# Altium schematic sheet CONTROL-PANEL.SchDoc
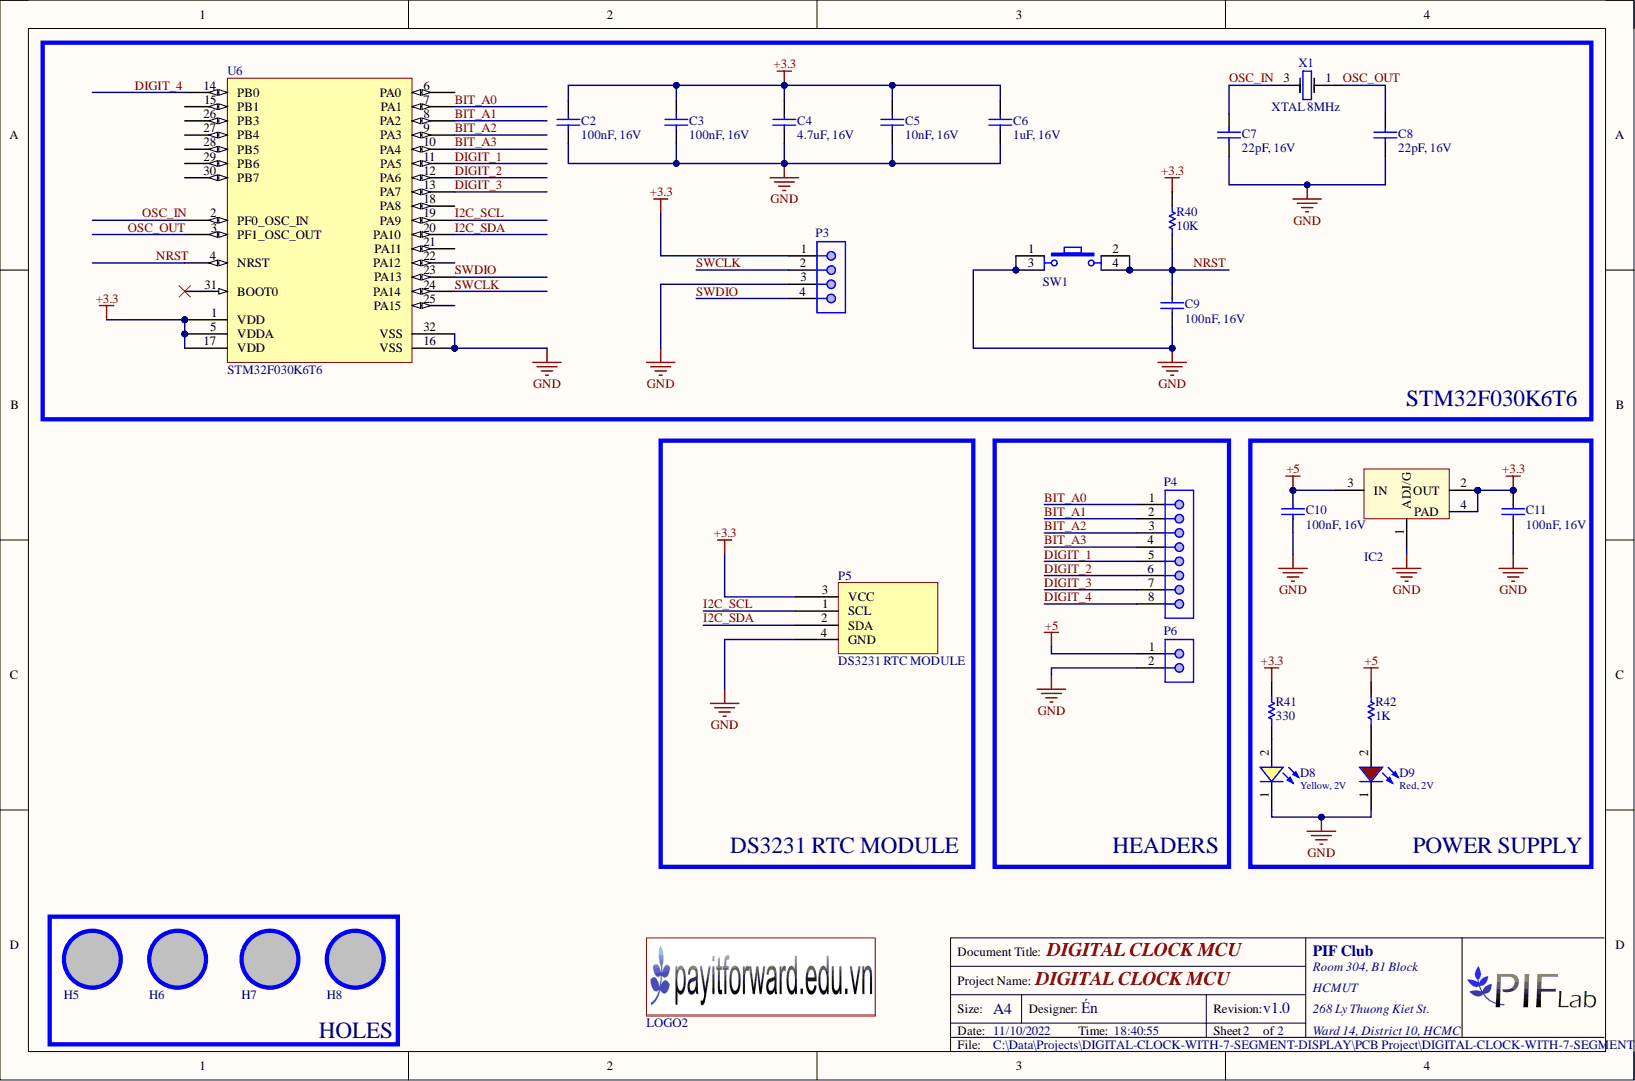
[diagram p0: CONTROL-PANEL.SchDoc]

## Parts
C2  value 100nF, 16V  [CAP-SM-0603]  (hidden comment: Cap Non-Pol SMD)
C3  value 100nF, 16V  [CAP-SM-0603]  (hidden comment: Cap Non-Pol SMD)
C4  value 4.7uF, 16V  [CAP-SM-0603]  (hidden comment: Cap Non-Pol SMD)
C5  value 10nF, 16V  [CAP-SM-0603]  (hidden comment: Cap Non-Pol SMD)
C6  value 1uF, 16V  [CAP-SM-0603]  (hidden comment: Cap Non-Pol SMD)
C7  value 22pF, 16V  [CAP-SM-0603]  (hidden comment: Cap Non-Pol SMD)
C8  value 22pF, 16V  [CAP-SM-0603]  (hidden comment: Cap Non-Pol SMD)
C9  value 100nF, 16V  [CAP-SM-0603]  (hidden comment: Cap Non-Pol SMD)
C10  value 100nF, 16V  [CAP-SM-0603]  (hidden comment: Cap Non-Pol SMD)
C11  value 100nF, 16V  [CAP-SM-0603]  (hidden comment: Cap Non-Pol SMD)
D8  Yellow, 2V  [WL-SMCW_0603_H=0.7mm]
D9  Red, 2V  Forward Voltage typ.: 2V  [WL-SMCW_0603_H=0.7mm]
H5  Hole  [LO KHOAN 3MM]  (value not drawn)
H6  Hole  [LO KHOAN 3MM]  (value not drawn)
H7  Hole  [LO KHOAN 3MM]  (value not drawn)
H8  Hole  [LO KHOAN 3MM]  (value not drawn)
IC2  LM1117  [SOT223-4N]  (value not drawn)
LOGO2  LOGO PIF  [PIF LOGO SMALL TOP]  (value not drawn)
P3  61900411121  [61900411121]  (value not drawn)
P4  62000811622  [62000811622]  (value not drawn)
P5  DS3231 RTC MODULE  [DS3231 RTC MODULE]
P6  62000211622  [62000211622]  (value not drawn)
R40  value 10K  [RES-SM-0603]  (hidden comment: RESISTOR SMD)
R41  value 330  [RES-SM-0603]  (hidden comment: RESISTOR SMD)
R42  value 1K  [RES-SM-0603]  (hidden comment: RESISTOR SMD)
SW1  SW  [Tact SW 4 pin]
U6  STM32F030K6T6  [STM32F030K6T6]
X1  XTAL 8MHz  [XTAL 3225]

## Nets
+3.3: C2.2 C3.2 C4.2 C5.2 C6.2 C11.2 IC2.2(OUT) IC2.4(PAD) P3.1 P5.3(VCC) R40.2 R41.2 U6.1(VDD) U6.5(VDDA) U6.17(VDD)
+5: C10.2 IC2.3(IN) P6.1 R42.2
GND: C2.1 C3.1 C4.1 C5.1 C6.1 C7.1 C8.1 C9.1 C10.1 C11.1 D8.1 D9.1 IC2.1(ADJ/G) P3.3 P5.4(GND) P6.2 SW1.1 SW1.3 U6.16(VSS) U6.32(VSS)
BIT_A0: P4.1 U6.7(PA1)
BIT_A1: P4.2 U6.8(PA2)
BIT_A2: P4.3 U6.9(PA3)
BIT_A3: P4.4 U6.10(PA4)
DIGIT_1: P4.5 U6.11(PA5)
DIGIT_2: P4.6 U6.12(PA6)
DIGIT_3: P4.7 U6.13(PA7)
DIGIT_4: P4.8 U6.14(PB0)
I2C_SCL: P5.1(SCL) U6.19(PA9)
I2C_SDA: P5.2(SDA) U6.20(PA10)
NRST: C9.2 R40.1 SW1.2 SW1.4 U6.4(NRST)
OSC_IN: C7.2 U6.2(PF0_OSC_IN) X1.3
OSC_OUT: C8.2 U6.3(PF1_OSC_OUT) X1.1
SWCLK: P3.2 U6.24(PA14)
SWDIO: P3.4 U6.23(PA13)
NetD8_2: D8.2 R41.1
NetD9_2: D9.2 R42.1

Not connected: U6 6(PA0) 15(PB1) 18(PA8) 21(PA11) 22(PA12) 25(PA15) 26(PB3) 27(PB4) 28(PB5) 29(PB6) 30(PB7) 31(BOOT0)

## Library data of the parts
C2 C10 = Cap Non-Pol SMD: Capacitor; Value: 100nF, 16V; Component Kind: Standard; Library Name: PIF_Library.IntLib
C3-C9 C11 = Cap Non-Pol SMD: Capacitor; Component Kind: Standard; Library Name: PIF_Library.IntLib
D8 = 150060YS75000: WL-SMCW SMT Mono-color Chip LED Waterclear, size 0603, Yellow, 2V, 140deg; Chip Technology: AlInGaP; Dominant Wavelength typ.: 590nm; Luminous Intensity typ.: 120mcd; Peak Wavelength typ.: 595nm; Component Kind: Standard; ComponentLink1Description: Manufacturer; ComponentLink1URL: http://www.we-online.de; ComponentLink2Description: Datasheet; ComponentLink2URL: https://www.we-online.com/catalog/datasheet/150060YS75000.pdf; Emitting Color: Yellow; Forward Voltage typ.: 2V; Library Name: Altium_WL-SMCW (rev20a).IntLib; Mounting Technology: SMT; Operating Temperature: -40 to +85degC; Packaging: Tape and Reel; Part Number: 150060YS75000; Published Date: December 2019; Size: 0603; Viewing Angle: 140deg; Publisher: Wurth Elektronik
D9 = 150060RS75000: WL-SMCW SMT Mono-color Chip LED Waterclear, size 0603, Red, 2V, 140deg; Chip Technology: AlInGaP; Dominant Wavelength typ.: 625nm; Luminous Intensity typ.: 250mcd; Peak Wavelength typ.: 630nm; Component Kind: Standard; ComponentLink1Description: Manufacturer; ComponentLink1URL: http://www.we-online.de; ComponentLink2Description: Datasheet; ComponentLink2URL: https://www.we-online.com/catalog/datasheet/150060RS75000.pdf; Emitting Color: Red; Library Name: Altium_WL-SMCW (rev20a).IntLib; Mounting Technology: SMT; Operating Temperature: -40 to +85degC; Packaging: Tape and Reel; Part Number: 150060RS75000; Published Date: December 2019; Size: 0603; Viewing Angle: 140deg; Publisher: Wurth Elektronik
H5-H8 = Hole: Hole 3mm; Component Kind: Standard; Library Name: PIF_Library.IntLib
IC2 = LM1117: Available in 1.8V, 2.5V, 2.85V, 3.3V, 5V, and  Adjustable Versions; Value: LM317; Component Kind: Standard; Library Name: PIF_Library.IntLib
P3 = 61900411121: WR-WTB THT Male Vertical Locking Header, pitch 2.54mm, 4p; Contact Resistance: 20mOhm; Pin Number: 4; Rated Current: 3A; Withstanding Voltage: 1000VAC; Working Voltage: 250VAC; Component Kind: Standard; ComponentLink1Description: Manufacturer; ComponentLink1URL: http://www.we-online.de; ComponentLink2Description: Datasheet; ComponentLink2URL: https://www.we-online.com/catalog/datasheet/61900411121.pdf; Gender: Male; Library Name: 653008114822_Altium_WR-WTB_rev20a.IntLib; Mounting Technology: THT; Operating Temperature: -40 to +105degC; Packaging: Bag; Part Number: 61900411121; Pitch: 2.54mm; Published Date: January 2020; Type: Vertical; Publisher: Wurth Elektronik
P4 = 62000811622: WR-WTB Male Vertical Shrouded Header, pitch 2mm, 8p; Contact Resistance: 20mOhm; Pin Number: 8; Rated Current: 2A; Withstanding Voltage: 800VAC; Working Voltage: 125VAC; Component Kind: Standard; ComponentLink1Description: Manufacturer; ComponentLink1URL: http://www.we-online.de; ComponentLink2Description: Datasheet; ComponentLink2URL: https://www.we-online.com/catalog/datasheet/62000811622.pdf; Gender: Male; Library Name: 653008114822_Altium_WR-WTB_rev20a.IntLib; Mounting Technology: THT; Operating Temperature: -25 to +85degC; Packaging: Bag; Part Number: 62000811622; Pitch: 2mm; Published Date: January 2020; Type: Vertical; Publisher: Wurth Elektronik
P6 = 62000211622: WR-WTB Male Vertical Shrouded Header, pitch 2mm, 2p; Contact Resistance: 20mOhm; Pin Number: 2; Rated Current: 2A; Withstanding Voltage: 800VAC; Working Voltage: 125VAC; Component Kind: Standard; ComponentLink1Description: Manufacturer; ComponentLink1URL: http://www.we-online.de; ComponentLink2Description: Datasheet; ComponentLink2URL: https://www.we-online.com/catalog/datasheet/62000211622.pdf; Gender: Male; Library Name: 653008114822_Altium_WR-WTB_rev20a.IntLib; Mounting Technology: THT; Operating Temperature: -25 to +85degC; Packaging: Bag; Part Number: 62000211622; Pitch: 2mm; Published Date: January 2020; Type: Vertical; Publisher: Wurth Elektronik
R40-R42 = RESISTOR SMD: Resistor; Component Kind: Standard; Library Name: PIF_Library.IntLib
SW1 = Tact SW - 4Pin: Switch button 4 pin; Value: Button chip 4 pin; Component Kind: Standard; Library Name: PIF_Library.IntLib
U6 = STM32F030K6T6: ARM Cortex M0 Microcontroller IC 32-Bit 48MHz 32KB FLASH, 32-LQFP; Mfr: STMicroelectronics; Connectivity: I²C, SPI, UART/USART; Number of I/O: 26; Peripherals: DMA, POR, PWM, WDT; Program Memory Size: 32KB (32K x 8); Component Kind: Standard; Package / Case: 32-LQFP; Program Memory Type1: FLASH; Speed: 48MHz; Library Name: Giang's Libs.IntLib
X1 = XTAL: Value: 16MHz; Component Kind: Standard; Library Name: MiclsLib.IntLib
LOGO2 = LOGO PIF: Component Kind: Standard; Library Name: PIF_Library.IntLib
P5 = DS3231 RTC MODULE: Component Kind: Standard; Library Name: Giang's Libs.IntLib
